# Altium schematic sheet EFR32MG24 Breakout Board Interface V1.1.SchDoc
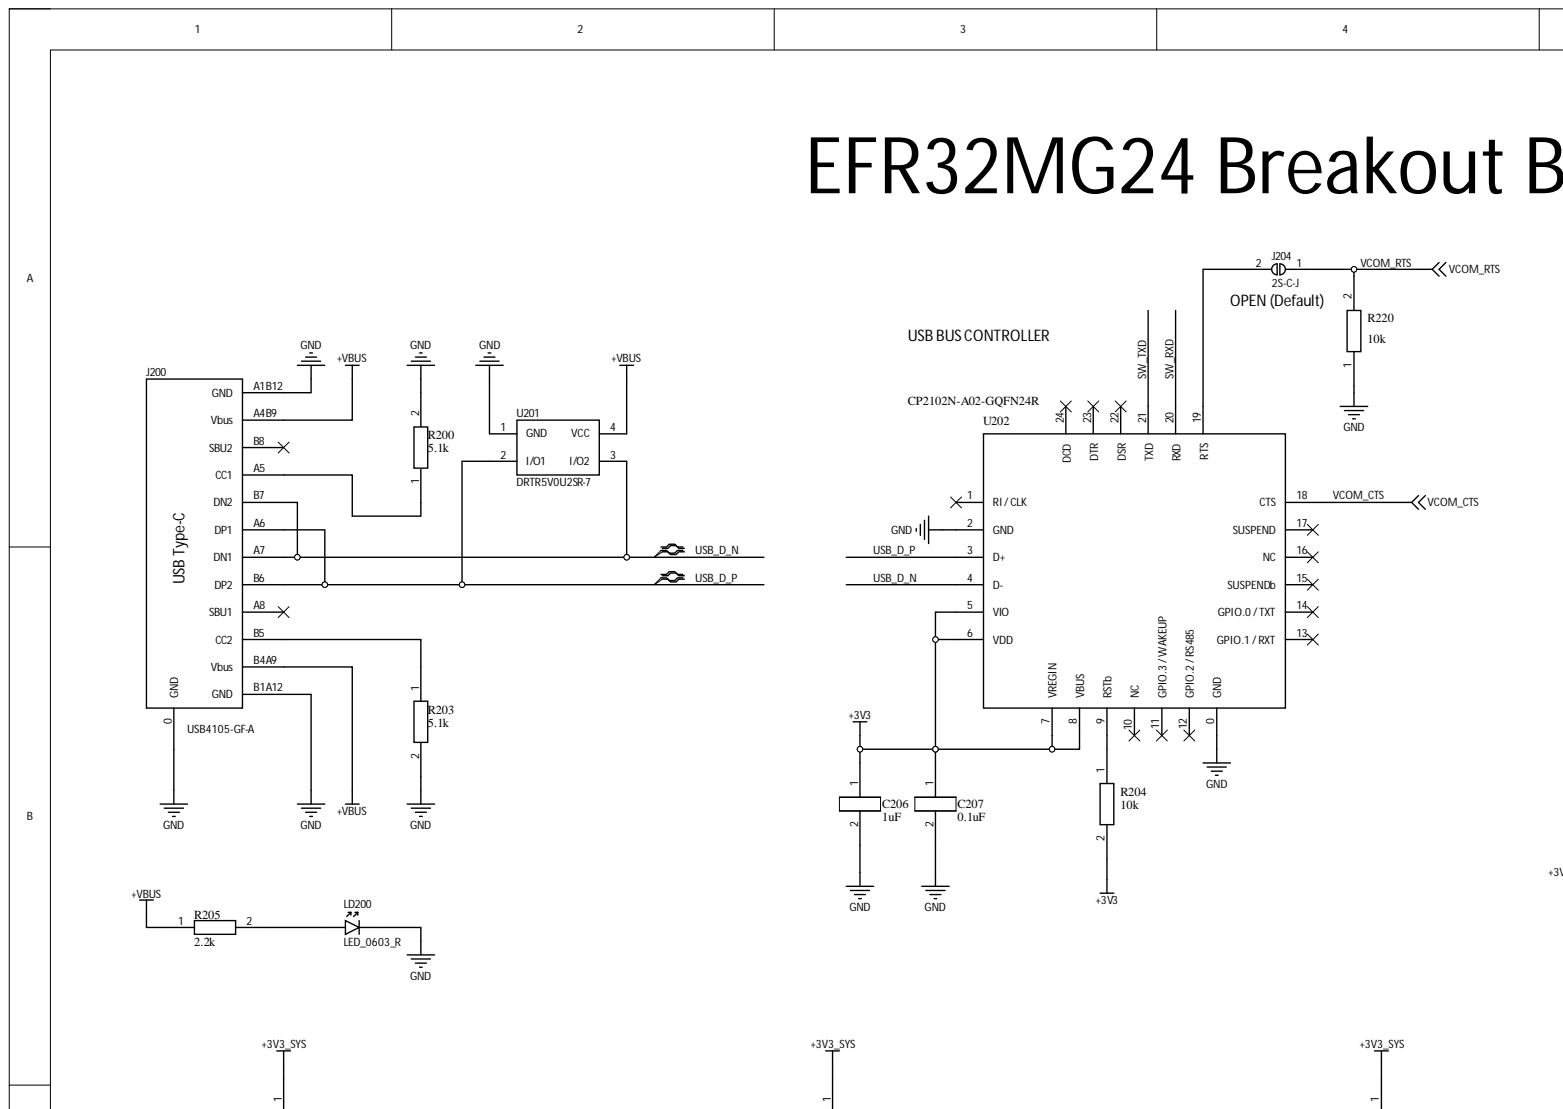
[diagram p0: EFR32MG24 Breakout Board Interface V1.1.SchDoc, top left]
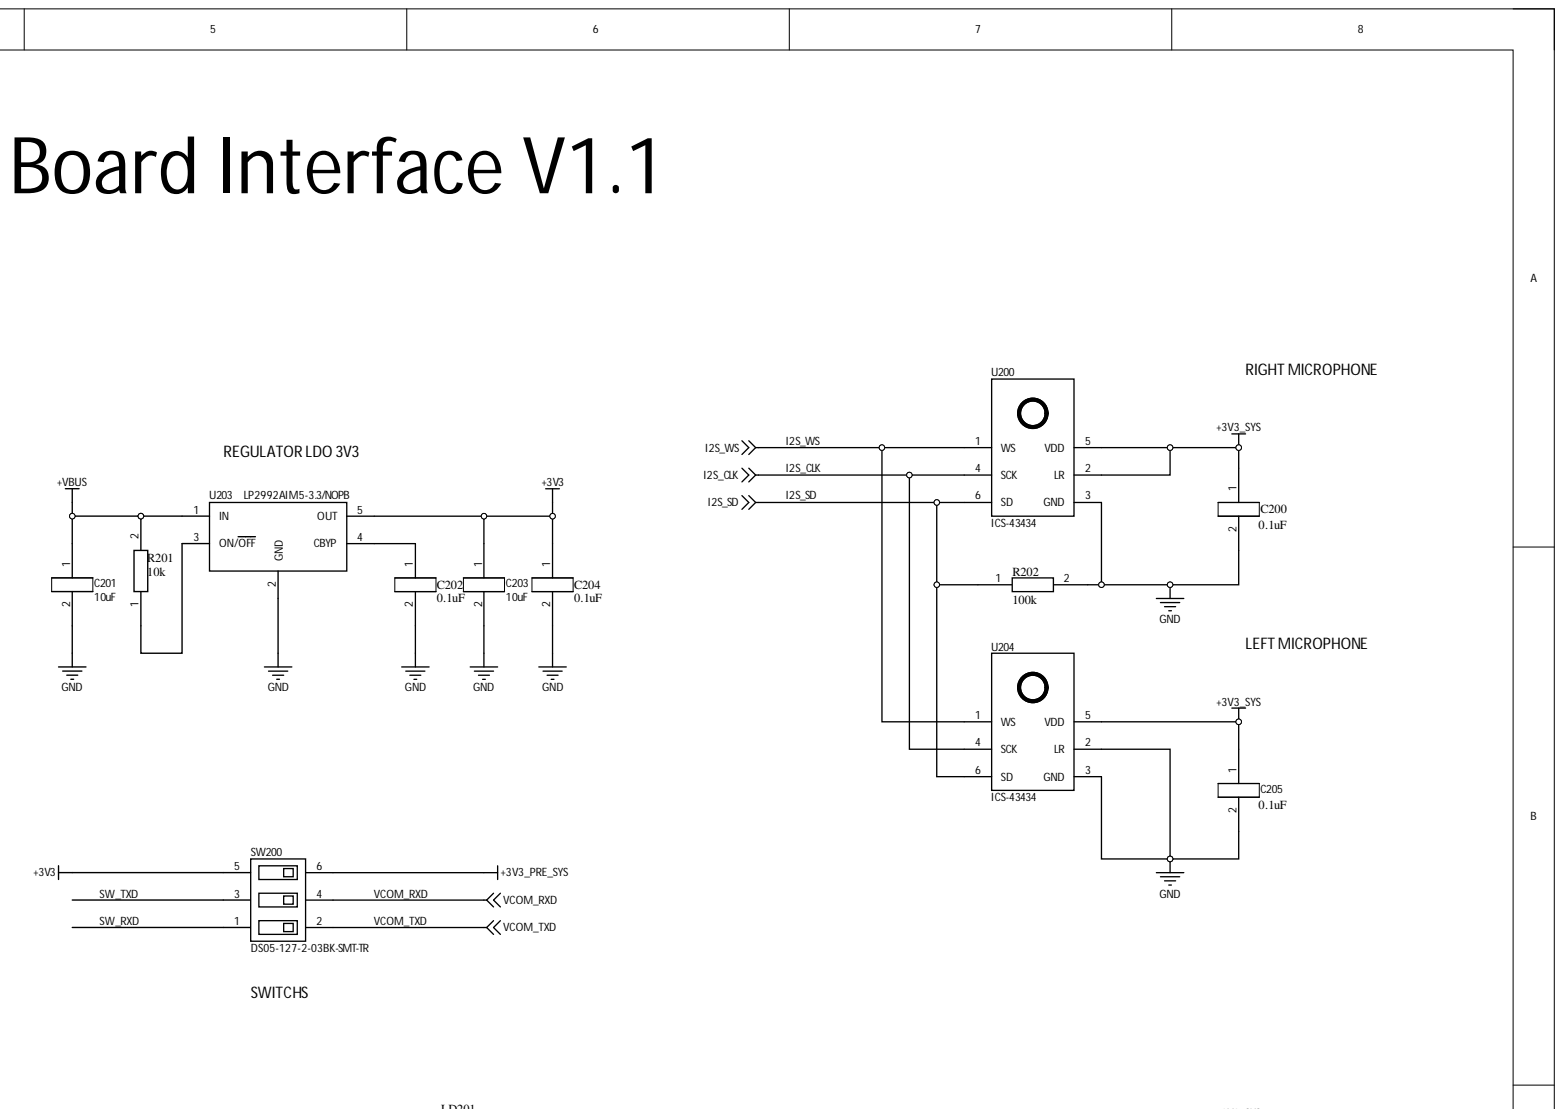
[diagram p1: EFR32MG24 Breakout Board Interface V1.1.SchDoc, top right]
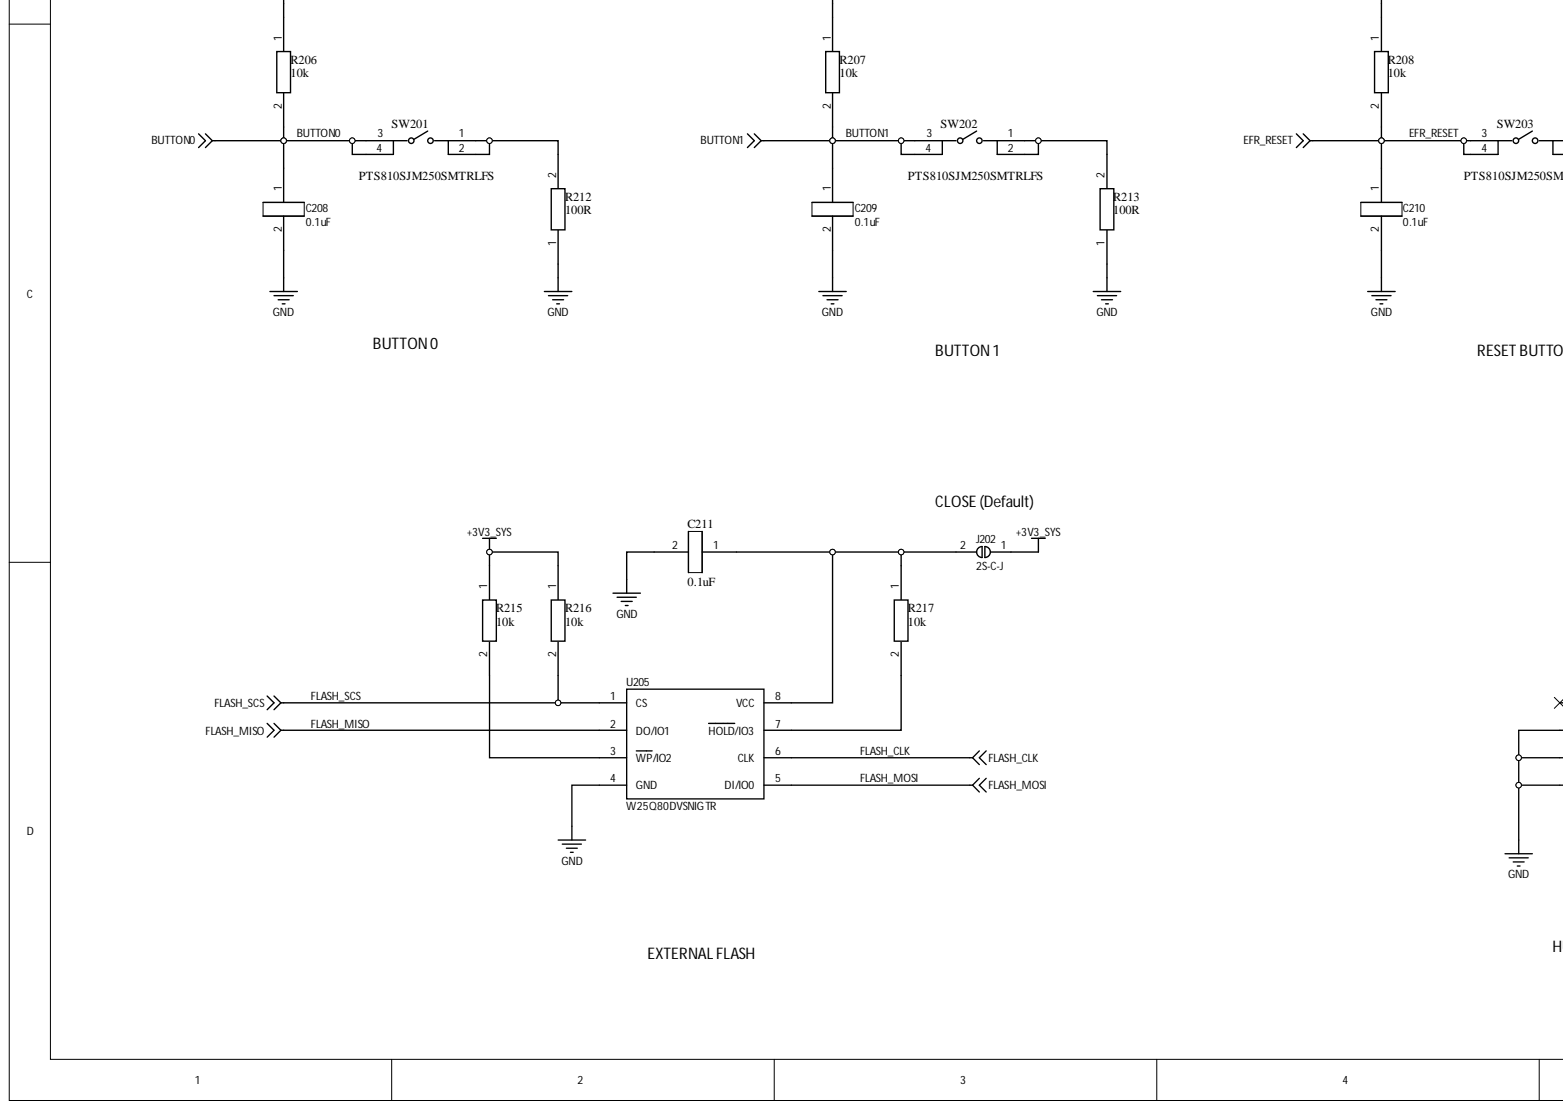
[diagram p2: EFR32MG24 Breakout Board Interface V1.1.SchDoc, bottom left]
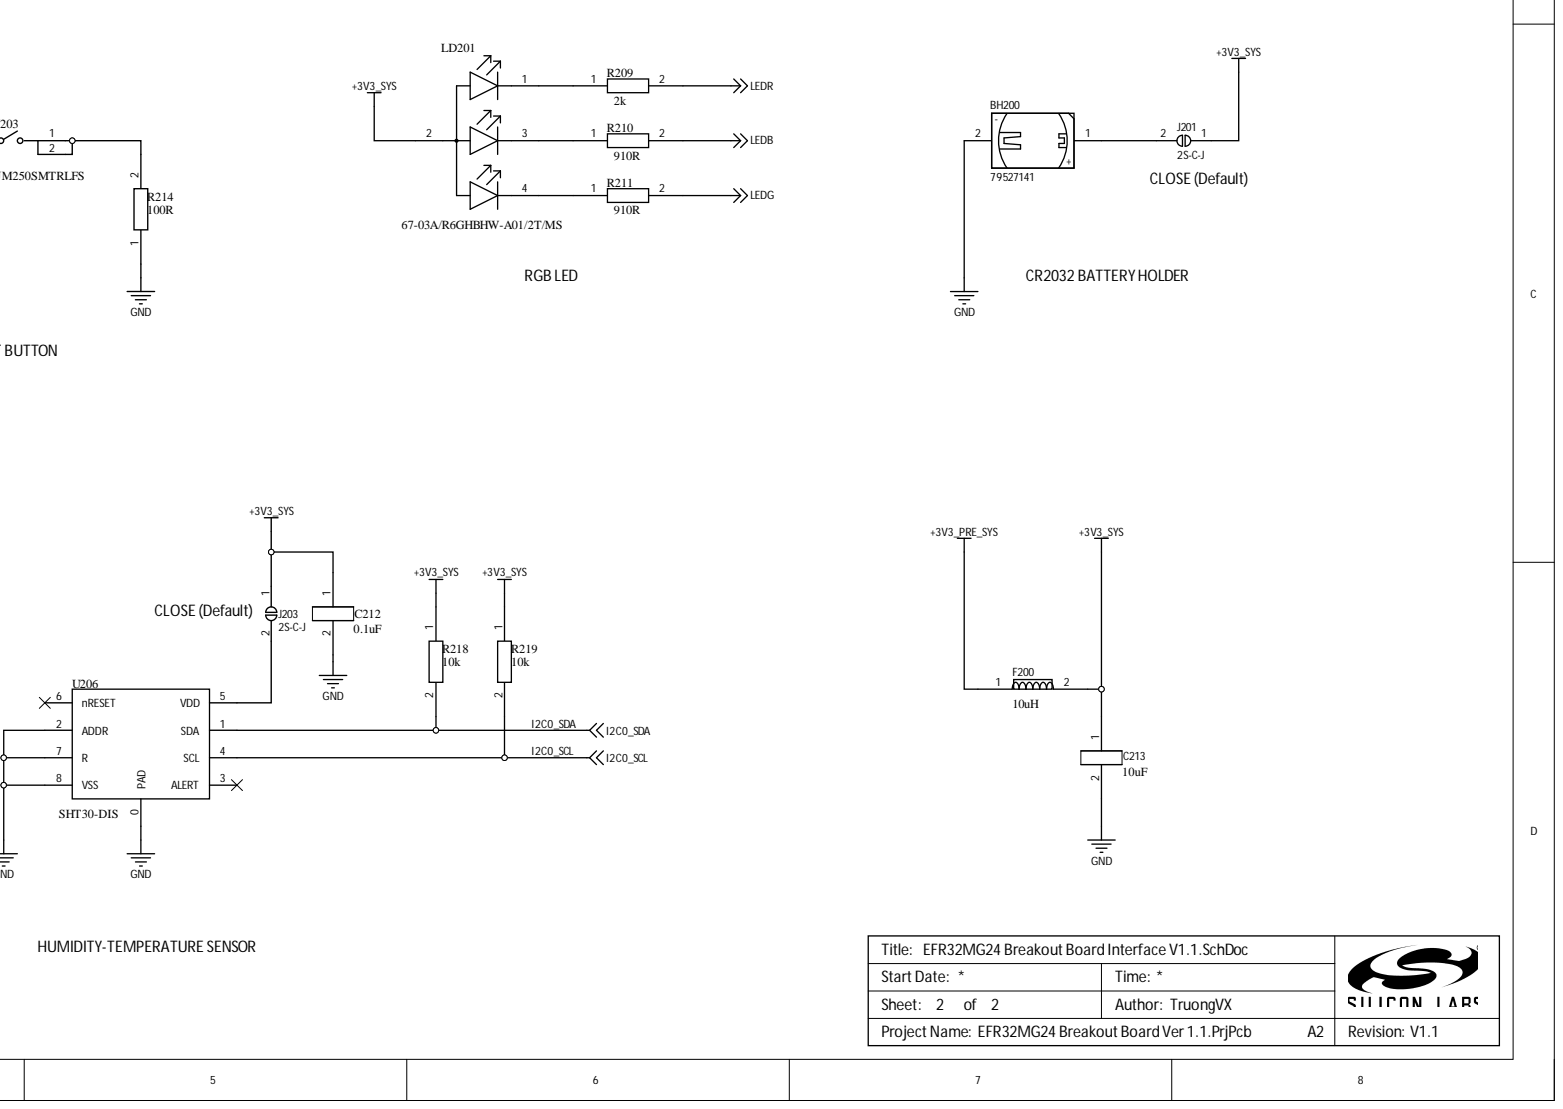
[diagram p3: EFR32MG24 Breakout Board Interface V1.1.SchDoc, bottom right]

## Parts
BH200  79527141  [79527141]
C200  0.1uF  [CAPC1005X05N0402]
C201  10uF  [CAPC1608X07N0603]
C202  0.1uF  [CAPC1005X05N0402]
C203  10uF  [CAPC1608X07N0603]
C204  0.1uF  [CAPC1005X05N0402]
C205  0.1uF  [CAPC1005X05N0402]
C206  1uF  [CAPC1005X05N0402]
C207  0.1uF  [CAPC1005X05N0402]
C208  0.1uF  [CAPC1005X05N0402]
C209  0.1uF  [CAPC1005X05N0402]
C210  0.1uF  [CAPC1005X05N0402]
C211  0.1uF  [CAPC1005X05N0402]
C212  0.1uF  [CAPC1005X05N0402]
C213  10uF  [CAPC1608X07N0603]
F200  10uH  [INDC1608X08N]
J200  USB4105-GF-A  [USB4105-GF-A]
J201  2S-C-J  [Solder-joint-1mm-Footprint-1]  (no BOM)
J202  2S-C-J  [Solder-joint-1mm-Footprint-1]  (no BOM)
J203  2S-C-J  [Solder-joint-1mm-Footprint-1]  (no BOM)
J204  2S-C-J  [Solder-joint-1mm-Footprint-1]  (no BOM)
LD200  LED_0603_R  [LEDC1608X06N-0603-RED]
LD201  67-03A/R6GHBHW-A01/2T/MS  [67-03A_R6GHBHW-A01_2T_MS-Footprint-1]
R200  5.1k  [RESC1005X04N0402]
R201  10k  [RESC1005X04N0402]
R202  100k  [RESC1005X04N0402]
R203  5.1k  [RESC1005X04N0402]
R204  10k  [RESC1005X04N0402]
R205  2.2k  [RESC1005X04N0402]
R206  10k  [RESC1005X04N0402]
R207  10k  [RESC1005X04N0402]
R208  10k  [RESC1005X04N0402]
R209  2k  [RESC1005X04N0402]
R210  910R  [RESC1005X04N0402]
R211  910R  [RESC1005X04N0402]
R212  100R  [RESC1005X04N0402]
R213  100R  [RESC1005X04N0402]
R214  100R  [RESC1005X04N0402]
R215  10k  [RESC1005X04N0402]
R216  10k  [RESC1005X04N0402]
R217  10k  [RESC1005X04N0402]
R218  10k  [RESC1005X04N0402]
R219  10k  [RESC1005X04N0402]
R220  10k  [RESC1005X04N0402]
SW200  DS05-127-2-03BK-SMT-TR  [DS05-127-2-03BK-SMT-TR]
SW201  PTS810SJM250SMTRLFS  [PTS810SJM250SMTRLFS-Footprint-1]
SW202  PTS810SJM250SMTRLFS  [PTS810SJM250SMTRLFS-Footprint-1]
SW203  PTS810SJM250SMTRLFS  [PTS810SJM250SMTRLFS-Footprint-1]
U200  ICS-43434  [ICS-43434]
U201  DRTR5V0U2SR-7  [SOT190P238X110-4N]
U202  CP2102N-A02-GQFN24R  [CP2102N-A02-GQFN24]
U203  LP2992AIM5-3.3/NOPB  [SOT95P248X145-5N]
U204  ICS-43434  [ICS-43434]
U205  W25Q80DVSNIG TR  [SOIC127P600X175-8N]
U206  SHT30-DIS  [SHT30_QFN50P250X250X100_HS-9N]

## Nets
+3V3: C203.1 C204.1 C206.1 C207.1 R204.2 SW200.5 U202.5(VIO) U202.6(VDD) U202.7(VREGIN) U202.8(VBUS) U203.5(OUT)
+3V3_PRE_SYS: F200.1 SW200.6
+3V3_SYS: C200.1 C205.1 C212.1 C213.1 F200.2 J201.1 J202.1 J203.1 LD201.2 R206.1 R207.1 R208.1 R215.1 R216.1 R218.1 R219.1 U200.2(LR) U200.5(VDD) U204.5(VDD)
+VBUS: C201.1 J200.A4B9(Vbus) J200.B4A9(Vbus) R201.2 R205.1 U201.4(VCC) U203.1(IN)
GND: BH200.2 C200.2 C201.2 C202.2 C203.2 C204.2 C205.2 C206.2 C207.2 C208.2 C209.2 C210.2 C211.2 C212.2 C213.2 J200.0(GND) J200.A1B12(GND) J200.B1A12(GND) LD200.2 R200.2 R202.2 R203.2 R212.1 R213.1 R214.1 R220.1 U200.3(GND) U201.1(GND) U202.0(GND) U202.2(GND) U203.2(GND) U204.2(LR) U204.3(GND) U205.4(GND) U206.0(PAD) U206.2(ADDR) U206.7(R) U206.8(VSS)
LEDB: R210.2
LEDG: R211.2
LEDR: R209.2
BUTTON0: C208.1 R206.2 SW201.3 SW201.4
BUTTON1: C209.1 R207.2 SW202.3 SW202.4
EFR_RESET: C210.1 R208.2 SW203.3 SW203.4
FLASH_CLK: U205.6(CLK)
FLASH_MISO: U205.2(DO/IO1)
FLASH_MOSI: U205.5(DI/IO0)
FLASH_SCS: R216.2 U205.1(CS)
I2C0_SCL: R219.2 U206.4(SCL)
I2C0_SDA: R218.2 U206.1(SDA)
I2S_CLK: U200.4(SCK) U204.4(SCK)
I2S_SD: R202.1 U200.6(SD) U204.6(SD)
I2S_WS: U200.1(WS) U204.1(WS)
SW_RXD: SW200.1 U202.20(RXD)
SW_TXD: SW200.3 U202.21(TXD)
USB_D_N: J200.A7(DN1) J200.B7(DN2) U201.3(I/O2) U202.4(D-)
USB_D_P: J200.A6(DP1) J200.B6(DP2) U201.2(I/O1) U202.3(D+)
VCOM_CTS: U202.18(CTS)
VCOM_RTS: J204.1 R220.2
VCOM_RXD: SW200.4
VCOM_TXD: SW200.2
NetBH200_1: BH200.1 J201.2
NetC202_1: C202.1 U203.4(CBYP)
NetC211_1: C211.1 J202.2 R217.1 U205.8(VCC)
NetJ200_A5: J200.A5(CC1) R200.1
NetJ200_B5: J200.B5(CC2) R203.1
NetJ203_2: J203.2 U206.5(VDD)
NetJ204_2: J204.2 U202.19(RTS)
NetLD200_1: LD200.1 R205.2
NetLD201_1: LD201.1 R209.1
NetLD201_3: LD201.3 R210.1
NetLD201_4: LD201.4 R211.1
NetR201_1: R201.1 U203.3(ON/OFF)
NetR204_1: R204.1 U202.9(RSTb)
NetR212_2: R212.2 SW201.1 SW201.2
NetR213_2: R213.2 SW202.1 SW202.2
NetR214_2: R214.2 SW203.1 SW203.2
NetR215_2: R215.2 U205.3(WP/IO2)
NetR217_2: R217.2 U205.7(HOLD/IO3)

Not connected: J200 A8(SBU1) B8(SBU2); U202 1(RI_/_CLK) 10(NC) 11(GPIO.3_/_WAKEUP) 12(GPIO.2_/_RS485) 13(GPIO.1_/_RXT) 14(GPIO.0_/_TXT) 15(SUSPENDb) 16(NC) 17(SUSPEND) 22(DSR) 23(DTR) 24(DCD); U206 3(ALERT) 6(nRESET)

## Library data of the parts
BH200 = 79527141: Battery Holder (Open) Coin, 20.0mm 1 Cell SMD (SMT) Tab; Manufacturer: Wurth Electronics; Number of Cells: 1; Component Kind: Standard; ComponentLink1Description: https://katalog.we-online.de/em/datasheet/79527141.pdf?src-supplier=Digi-Key; ComponentLink1URL: https://katalog.we-online.de/em/datasheet/79527141.pdf?src-supplier=Digi-Key; Library Name: MG21 Breakout Board.IntLib; Manufacturer Part Number: 79527141; Max Operating Temperature: 85°C; Min Operating Temperature: -55°C; Mount: Surface Mount,PCB; Pricing 1: 1=1.86000, 10=1.79400, 50=1.58700, 100=1.38000, 250=1.24200 (USD); RoHS: Yes; RoHS Compliant: Yes; Stock 1: 2540; Supplier 1: Digi-Key; Supplier Part Number 1: 732-12325-1-ND; Termination: SMD/SMT
C200 C202 C204-C212 = CAP0402: Capacitor 0402; Component Kind: Standard; Package: 0402
  C200 C205 C212: Library Name: EP4185.IntLib; Value: 0.1uF
  C202 C204 C207-C211: Library Name: MG21 Breakout Board.IntLib; Value: 0.1uF
  C206: Library Name: MG21 Breakout Board.IntLib; Value: 1uF
C201 C203 C213 = CAP0603: Capacitor 0603; Component Kind: Standard; Package: 0603; Value: 10uF
  C201 C203: Library Name: MG21 Breakout Board.IntLib
  C213: Library Name: EP4185.IntLib
F200 = IND0603: Inductor 0603; Component Kind: Standard; Inductance: 10uH; Library Name: EP4185.IntLib; Package: 0603; Value: 10uH
J200 = USB4105-GF-A: USB-C (USB TYPE-C) USB 2.0 Receptacle Connector 24 (16+8 Dummy) Position Surface Mount, Right Angle; Through Hole; Manufacturer: Global Connector Technology; Component Kind: Standard; Library Name: MG21 Breakout Board.IntLib; Manufacturer Part Number: USB4105-GF-A; Pricing 1: 800=1.19000, 1600=1.07000, 2400=1.01000, 4000=0.98000, 8000=0.96000, 20000=0.90000 (USD); RoHS: No; Stock 1: 800; Supplier 1: Newark; Supplier Part Number 1: 12AJ7324
J201-J204 = 2S-C-J: 2 Points Semi-Circle Jumper; Library Name: EP4185.IntLib
LD200 = LED_0603_R: Chip LED (Red), 2-Leads, Body 1.60x0.80mm, IPC Medium Density; Maker: Leo; Component Kind: Standard; Library Name: MG21 Breakout Board.IntLib
LD201 = CMP-007-00039-2: 3 in 1 full color SMD LEDs,3.5*2.8*1.84mm; Component Kind: Standard; Library Name: Silicon Laboratories
R200-R220 = RES0402: Resistor 0402; Power: 1/16W; Tolerance: 1%; Component Kind: Standard; Package: 0402
  R200 R203: Library Name: MG21 Breakout Board.IntLib; Value: 5.1k
  R201 R204 R206-R208 R215-R217 R219: Library Name: MG21 Breakout Board.IntLib; Value: 10k
  R202: Library Name: EP4185.IntLib; Value: 100k
  R205: Library Name: MG21 Breakout Board.IntLib; Value: 2.2k
  R209: Library Name: EP4185.IntLib; Value: 2k
  R210 R211: Library Name: EP4185.IntLib; Value: 910R
  R212-R214: Library Name: MG21 Breakout Board.IntLib; Value: 100R
  R218 R220: Library Name: EP4185.IntLib; Value: 10k
SW200 = DS05-127-2-03BK-SMT-TR: Dip Switch SPST 3 Position Surface Mount Slide (Standard) Actuator 25mA 24VDC; Manufacturer: CUI Devices; Component Kind: Standard; Library Name: MG21 Breakout Board.IntLib; Manufacturer Part Number: DS05-127-2-03BK-SMT-TR; Pricing 1: 2000=0.94240 (USD); RoHS: Yes; Supplier 1: Digi-Key; Supplier Part Number 1: 2223-DS05-127-2-03BK-SMT-TR-ND
SW201-SW203 = CMP-002-00072-4: SWITCH TACTILE SPST-NO 0.05A 16V; Actuator Type: Standard; Current Rating: 50mA; Illumination Type: Non-Illuminated; Mechanical Life: 150000Cycles; Operating Force: 160gf; Switch Function: Off-Mom; Switch Travel: 0.15mm; Throw Configuration: SPST; Case/Package: SMD/SMT; Color: Black; Component Kind: Standard; Contact Plating: Silver; Depth: 4.6mm; Lead Free: Lead Free; Length: 3.2mm; Max Current Rating: 50mA; Max Operating Temperature: 85°C; Min Operating Temperature: -40°C; Mount: Surface Mount; Orientation: Straight; Package Quantity: 2900; Packaging: Tape and Reel; Termination: J-Bend; Voltage Rating (DC): 16V; Library Name: Silicon Laboratories
U200 U204 = CMP-096-000019-1: Consumer Circuit; Manufacturer: TDK InvenSense; Category: Microphones; Component Kind: Standard; Component Type: Standard; ComponentLink1Description: https://datasheet.ciiva.com:443/pdfs/VipMasterIC/IC/IVNC/IVNC-S-A0005715430/IVNC-S-A0005715430-1.pdf?src-supplier=IHS+Markit; ComponentLink1URL: https://datasheet.ciiva.com:443/pdfs/VipMasterIC/IC/IVNC/IVNC-S-A0005715430/IVNC-S-A0005715430-1.pdf?src-supplier=IHS+Markit; ComponentLink2Description: http://www.farnell.com/datasheets/2632666.pdf?src-supplier=Farnell; ComponentLink2URL: http://www.farnell.com/datasheets/2632666.pdf?src-supplier=Farnell; ComponentLink3Description: https://www.invensense.com/wp-content/uploads/2016/02/PB-000018-ICS-43434-v1.1.pdf?src-supplier=Digi-Key; ComponentLink3URL: https://www.invensense.com/wp-content/uploads/2016/02/PB-000018-ICS-43434-v1.1.pdf?src-supplier=Digi-Key; ComponentLink4Description: http://www.farnell.com/datasheets/2632666.pdf?src-supplier=Element14; ComponentLink4URL: http://www.farnell.com/datasheets/2632666.pdf?src-supplier=Element14; ComponentLink5Description: https://www.invensense.com/wp-content/uploads/2016/02/DS-000069-ICS-43434-v1.2.pdf?src-supplier=Digi-Key; ComponentLink5URL: https://www.invensense.com/wp-content/uploads/2016/02/DS-000069-ICS-43434-v1.2.pdf?src-supplier=Digi-Key; ComponentLink6Description: http://www.farnell.com/datasheets/2632666.pdf?src-supplier=Newark; ComponentLink6URL: http://www.farnell.com/datasheets/2632666.pdf?src-supplier=Newark; Consumer IC Type: CONSUMER CIRCUIT; Design Item ID: ICS-43434; Manufacturer Part Number: ICS-43434; Pin Count: 6; Pricing 1: 1=3.09000, 10=2.56000, 25=2.41000, 50=2.26000, 100=2.11000 (USD); REACH SVHC: No SVHC; RoHS: No; RoHS Compliant: No; Source: EP4185.SchLib; Stock 1: 637; Library Name: Silicon Laboratories
U201 = DRTR5V0U2SR-7: TVS DIODE 5.5V SOT143; Manufacturer: Diodes; Direction: Unidirectional; Element Configuration: Dual; ESD Protection: Yes; Max Reverse Leakage Current: 100nA; Min Breakdown Voltage: 6V; Number of Bidirectional Channels: 2; Peak Pulse Current: 5A; Peak Pulse Power: 400mW; Test Current: 1mA; Case/Package: SOT-143; Category: TVS Diodes; Component Kind: Standard; ComponentLink1Description: https://datasheet.ciiva.com/29588/drtr5v0u2sr-29588600.pdf?src-supplier=Digi-Key; ComponentLink1URL: https://datasheet.ciiva.com/29588/drtr5v0u2sr-29588600.pdf?src-supplier=Digi-Key; ComponentLink2Description: https://datasheet.ciiva.com/29588/drtr5v0u2sr-29588602.pdf?src-supplier=Digi-Key; ComponentLink2URL: https://datasheet.ciiva.com/29588/drtr5v0u2sr-29588602.pdf?src-supplier=Digi-Key; ComponentLink3Description: https://datasheet.ciiva.com/48480/drtr5v0u2sr-48480031.pdf?src-supplier=Avnet; ComponentLink3URL: https://datasheet.ciiva.com/48480/drtr5v0u2sr-48480031.pdf?src-supplier=Avnet; Height: 1mm; Length: 3mm; Library Name: MG21 Breakout Board.IntLib; Manufacturer Part Number: DRTR5V0U2SR-7; Max Operating Temperature: 150°C; Min Operating Temperature: -65°C; Mount: Surface Mount; Number of Pins: 4; Packaging: Tape and Reel; Power Line Protection: Yes; Pricing 1: 1=0.40000, 10=0.32300, 100=0.22000, 500=0.16500, 1000=0.12375 (USD); Radiation Hardening: No; Reverse Standoff Voltage: 5.5V; RoHS: Yes; RoHS Compliant: Yes; Stock 1: 11604; Supplier 1: Digi-Key; Supplier Part Number 1: DRTR5V0U2SR-7DICT-ND; Width: 1.4mm
U202 = CMP-015-00009-1: USB Bus Controller, CMOS, -40 to +85 °C, QFN24; Component Kind: Standard; Library Name: Silicon Laboratories
U203 = LP2992AIM5-3.3/NOPB: IC REG LDO 3.3V 0.25A SOT23-5; Manufacturer: Texas Instruments; Current - Output: 250mA; Maker: Leo; Number of Regulators: 1; Regulator Topology: Positive Fixed; Voltage - Dropout (Typical): 0.45V @ 250mA; Voltage - Input: Up to 16V; Category: Integrated Circuits (ICs); Component Kind: Standard; ComponentLink1Description: http://www.ti.com/lit/ds/symlink/lp2992.pdf; ComponentLink1URL: http://www.ti.com/lit/ds/symlink/lp2992.pdf; Current - Limit (Min): -; Library Name: MG21 Breakout Board.IntLib; Manufacturer Part Number: LP2992AIM5-3.3/NOPB; Mounting Type: Surface Mount; Operating Temperature: -40°C ~ 125°C; Package / Case: SC-74A, SOT-753; Packaging: Tape & Reel (TR); Pricing 1: 1000=0.4275 (USD); RoHS: RoHS Compliant; SchLibComponentName: LP2992AIM5-3.3/NOPB; Stock 1: 28000; Supplier 1: Digi-Key; Supplier Device Package: SOT-23-5; Supplier Part Number 1: LP2992AIM5-3.3/NOPBTR-ND; Voltage - Output: 3.3V
U205 = W25Q80DVSNIG TR: IC FLASH 8M SPI 104MHZ 8SOIC; Manufacturer: Winbond; Access Time: 6ns; Address Bus Width: 24b; Density: 8MB; Interface: SPI,Serial; Max Supply Voltage: 3.6V; Memory Type: FLASH,NOR; Min Supply Voltage: 2.7V; Operating Supply Voltage: 3V; Page Size: 256B; Case/Package: SOIC; Category: Flash; Component Kind: Standard; ComponentLink1Description: https://www.winbond.com/resource-files/w25q80dv_revg_07212015.pdf?src-supplier=Avnet+Asia; ComponentLink1URL: https://www.winbond.com/resource-files/w25q80dv_revg_07212015.pdf?src-supplier=Avnet+Asia; ComponentLink2Description: http://www.winbond.com/resource-files/w25q80dv%20dl_revh_10022015.pdf?src-supplier=Digi-Key; ComponentLink2URL: http://www.winbond.com/resource-files/w25q80dv%20dl_revh_10022015.pdf?src-supplier=Digi-Key; ComponentLink3Description: https://www.winbond.com/resource-files/w25q80dv_revg_07212015.pdf?src-supplier=Digi-Key; ComponentLink3URL: https://www.winbond.com/resource-files/w25q80dv_revg_07212015.pdf?src-supplier=Digi-Key; Frequency: 104MHz; Library Name: MG21 Breakout Board.IntLib; Manufacturer Part Number: W25Q80DVSNIG TR; Max Operating Temperature: 85°C; Min Operating Temperature: -40°C; Mount: Surface Mount; Number of Pins: 8; Packaging: Cut Tape; Pricing 1: 1=0.55000, 10=0.53800, 25=0.49920, 50=0.49660, 100=0.44100, 250=0.43544, 500=0.42884, 1000=0.41739 (USD); RoHS: Yes; Stock 1: 77930; Supplier 1: Digi-Key; Supplier Part Number 1: W25Q80DVSNIGCT-ND
U206 = CMP-010-00026-3: Humidity and Temperature Sensor;8-pin DFN,2.5*2.5*0.9mm; Component Kind: Standard; Library Name: Silicon Laboratories
